# Altium schematic sheet mcu.SchDoc(mcu)
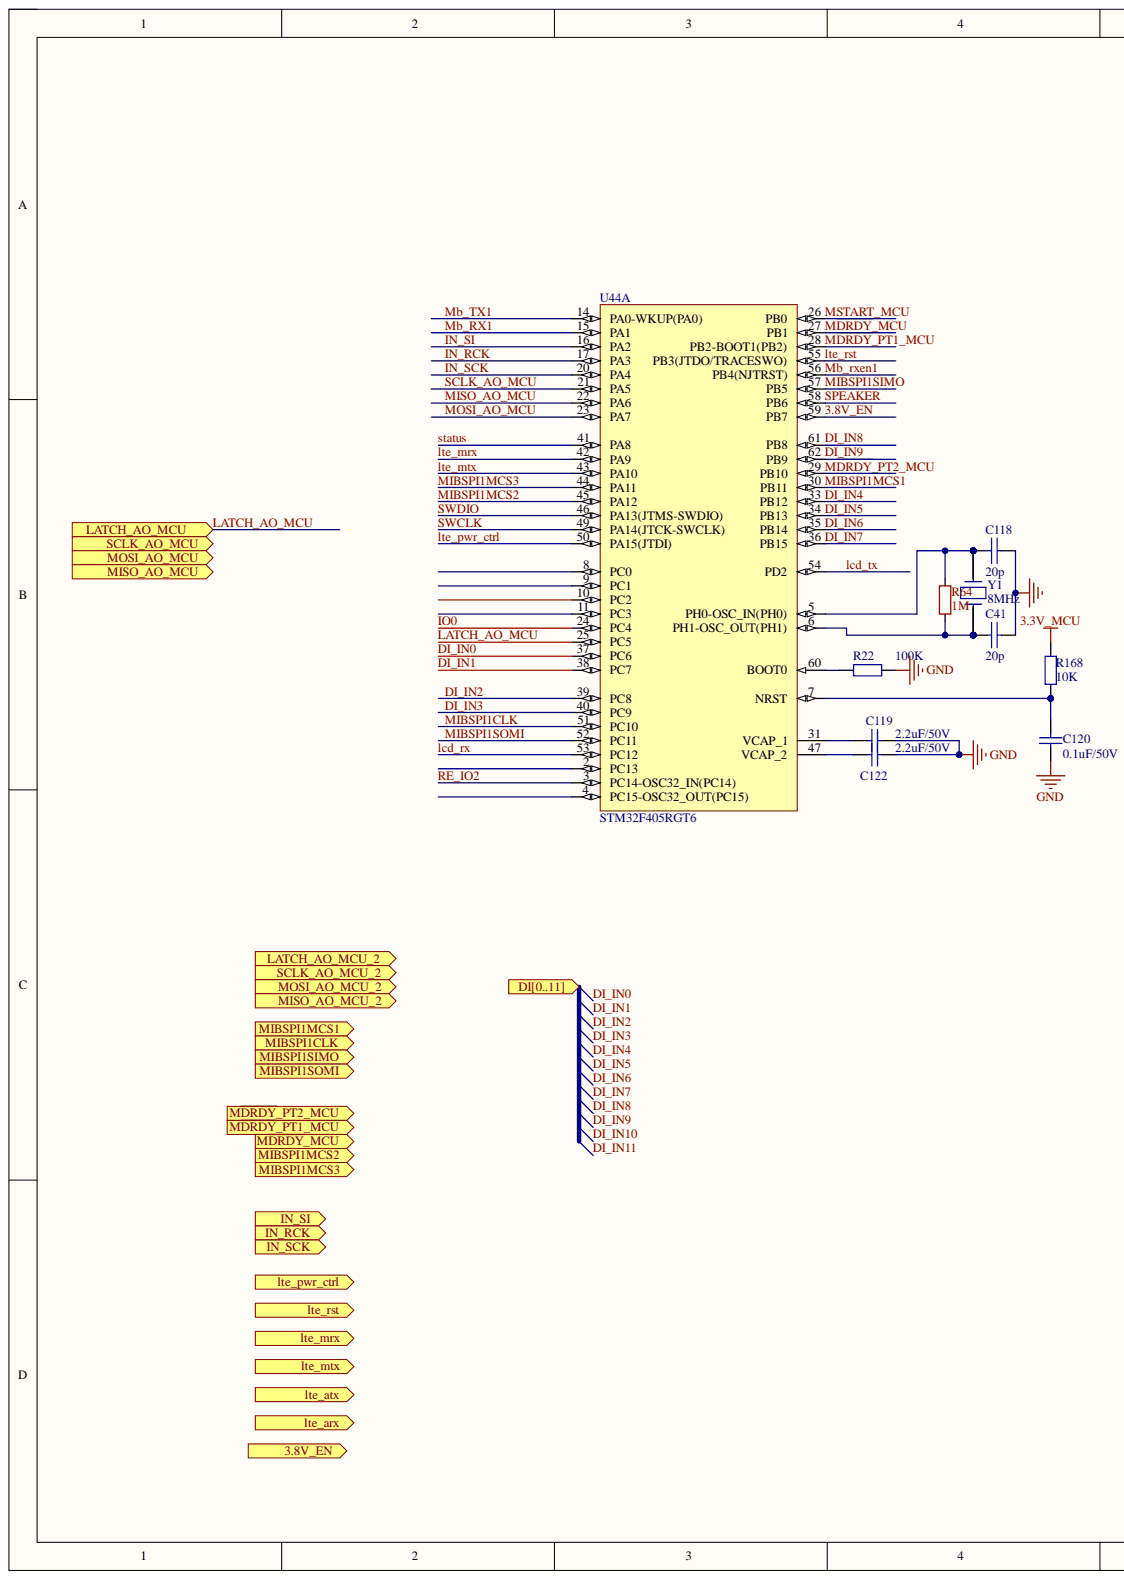
[diagram p0: mcu.SchDoc, left]
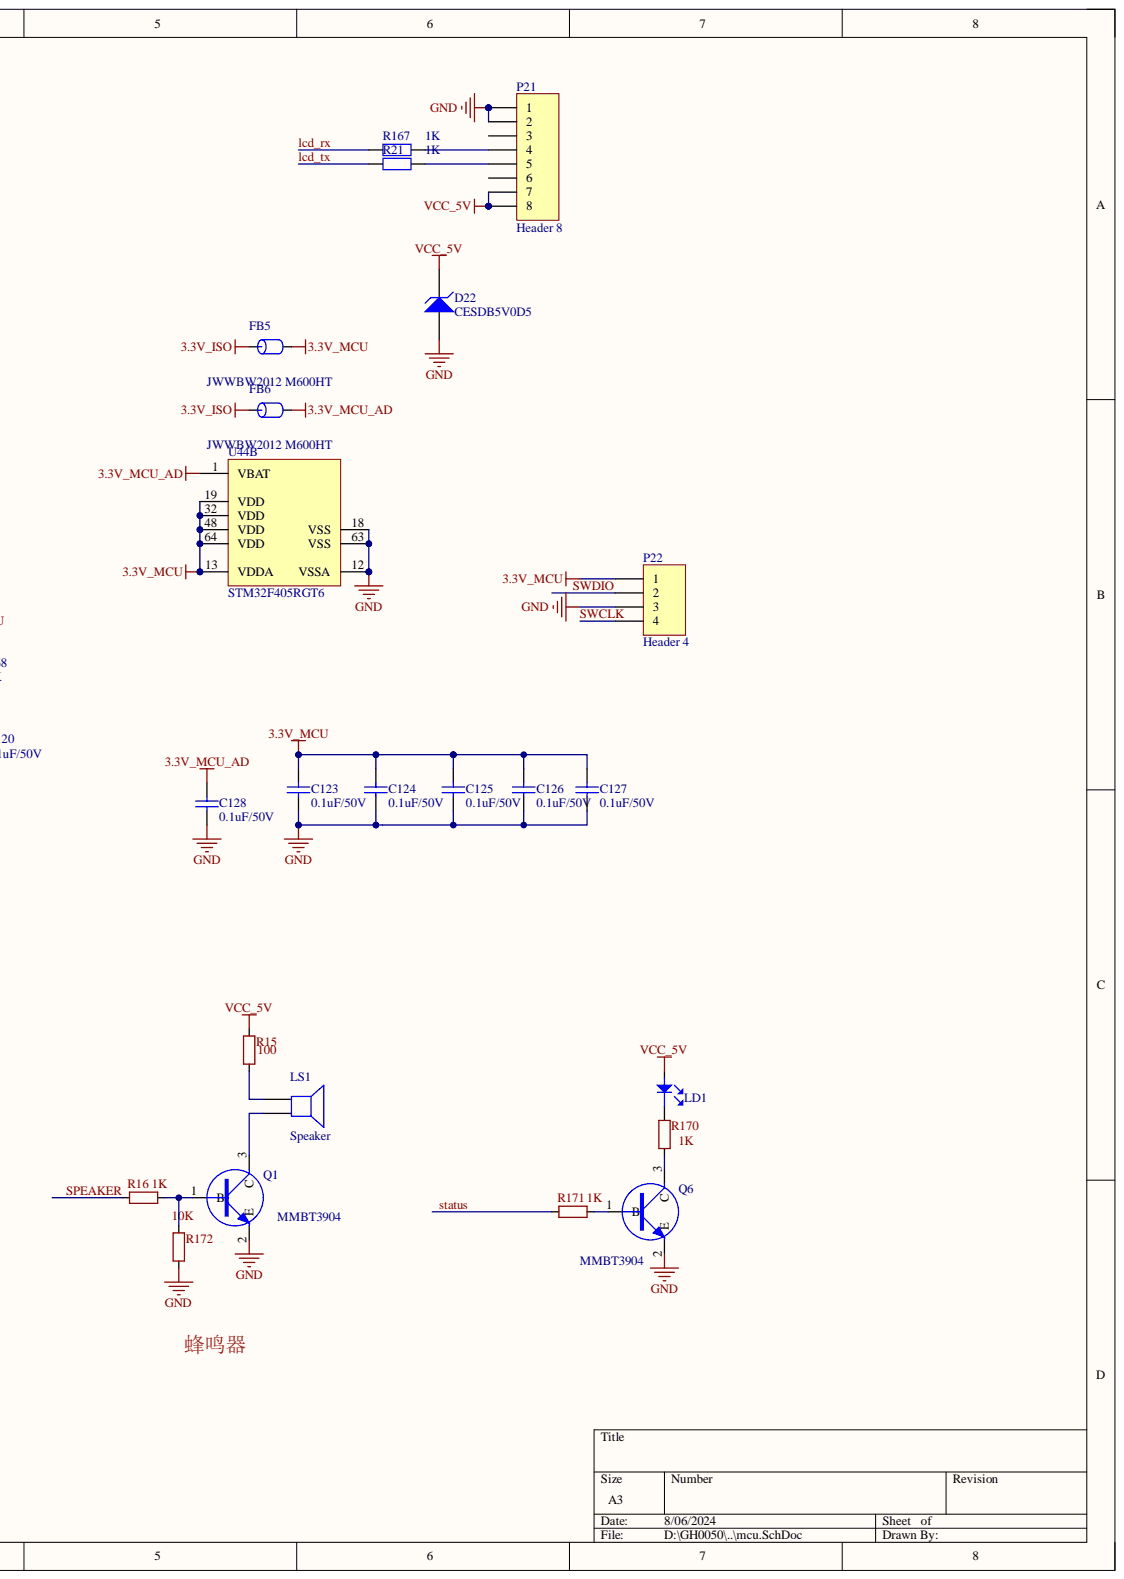
[diagram p1: mcu.SchDoc, right]

## Parts
C41  20p  [0603c]
C118  20p  [0603c]
C119  2.2uF/50V  [0603C]
C120  0.1uF/50V  [0603C]
C122  2.2uF/50V  [0603C]
C123  0.1uF/50V  [0603C]
C124  0.1uF/50V  [0603C]
C125  0.1uF/50V  [0603C]
C126  0.1uF/50V  [0603C]
C127  0.1uF/50V  [0603C]
C128  0.1uF/50V  [0603C]
D22  CESDB5V0D5  [sod323]
FB5  JWWBW2012 M600HT  [0805R]
FB6  JWWBW2012 M600HT  [0805R]
LD1  LED_Green_19-217  [0603led]  (value not drawn)
LS1  Speaker  [BUFFER_0905]
P21  Header 8  [2.0x8]  (no BOM)
P22  Header 4  [HDR1X4]
Q1  MMBT3904  [SOT23-3]
Q6  MMBT3904  [SOT23-3]
R15  100  [0603R]
R16  1K  [0603r]
R21  1K  [0603R]
R22  100K  [0603R]
R64  1M  [0603R]
R167  1K  [0603R]
R168  10K  [0603R]
R170  1K  [0603r]
R171  1K  [0603r]
R172  10K  [0603r]
U44  STM32F405RGT6  [LQFP-64]  (parts A,B)
Y1  8MHz  [CRAY_5032]

## Ports
3.8V_EN, DI0, DI1, DI2, DI3, DI4, DI5, DI6, DI7, DI8, DI9, DI10, DI11, DI[0..11], IN_RCK, IN_SCK, IN_SI, LATCH_AO_MCU, LATCH_AO_MCU_2, lte_arx, lte_atx, lte_mrx, lte_mtx, lte_pwr_ctrl, lte_rst, MIBSPI1CLK, MIBSPI1MCS1, MIBSPI1MCS2, MIBSPI1MCS3, MIBSPI1SIMO, MIBSPI1SOMI, MISO_AO_MCU, MISO_AO_MCU_2, MOSI_AO_MCU, MOSI_AO_MCU_2, M~{DRDY}_MCU, M~{DRDY}_PT1_MCU, M~{DRDY}_PT2_MCU, SCLK_AO_MCU, SCLK_AO_MCU_2

## Nets
3.3V_ISO: FB5.1 FB6.1
3.3V_MCU: C123.2 C124.2 C125.2 C126.2 C127.2 FB5.2 P22.1 R168.2 U44.13(VDDA) U44.19(VDD) U44.32(VDD) U44.48(VDD) U44.64(VDD)
3.3V_MCU_AD: C128.2 FB6.2 U44.1(VBAT)
GND: C41.1 C118.1 C119.1 C120.1 C122.1 C123.1 C124.1 C125.1 C126.1 C127.1 C128.1 D22.1 P21.1 P21.2 P22.3 Q1.2 Q6.2 R22.2 R172.2 U44.12(VSSA) U44.18(VSS) U44.63(VSS)
VCC_5V: D22.2 LD1.1 P21.7 P21.8 R15.1
3.8V_EN: U44.59(PB7)
DI_IN0: U44.37(PC6)
DI_IN1: U44.38(PC7)
DI_IN2: U44.39(PC8)
DI_IN3: U44.40(PC9)
DI_IN4: U44.33(PB12)
DI_IN5: U44.34(PB13)
DI_IN6: U44.35(PB14)
DI_IN7: U44.36(PB15)
DI_IN8: U44.61(PB8)
DI_IN9: U44.62(PB9)
IN_RCK: U44.17(PA3)
IN_SCK: U44.20(PA4)
IN_SI: U44.16(PA2)
IO0: U44.24(PC4)
LATCH_AO_MCU: U44.25(PC5) ; port LATCH_AO_MCU
lcd_rx: R167.1 U44.53(PC12)
lcd_tx: R21.1 U44.54(PD2)
lte_mrx: U44.42(PA9)
lte_mtx: U44.43(PA10)
lte_pwr_ctrl: U44.50(PA15(JTDI))
lte_rst: U44.55(PB3(JTDO/TRACESWO))
Mb_RX1: U44.15(PA1)
Mb_rxen1: U44.56(PB4(NJTRST))
Mb_TX1: U44.14(PA0-WKUP(PA0))
M~{DRDY}_MCU: U44.27(PB1)
M~{DRDY}_PT1_MCU: U44.28(PB2-BOOT1(PB2))
M~{DRDY}_PT2_MCU: U44.29(PB10)
MIBSPI1CLK: U44.51(PC10)
MIBSPI1MCS1: U44.30(PB11)
MIBSPI1MCS2: U44.45(PA12)
MIBSPI1MCS3: U44.44(PA11)
MIBSPI1SIMO: U44.57(PB5)
MIBSPI1SOMI: U44.52(PC11)
MISO_AO_MCU: U44.22(PA6)
MOSI_AO_MCU: U44.23(PA7)
MSTART_MCU: U44.26(PB0)
RE_IO2: U44.3(PC14-OSC32_IN(PC14))
SCLK_AO_MCU: U44.21(PA5)
SPEAKER: R16.2 U44.58(PB6)
status: R171.1 U44.41(PA8)
SWCLK: P22.4 U44.49(PA14(JTCK-SWCLK))
SWDIO: P22.2 U44.46(PA13(JTMS-SWDIO))
NetC41_2: C41.2 R64.1 U44.6(PH1-OSC_OUT(PH1)) Y1.2
NetC118_2: C118.2 R64.2 U44.5(PH0-OSC_IN(PH0)) Y1.1
NetC119_2: C119.2 U44.31(VCAP_1)
NetC120_2: C120.2 R168.1 U44.7(NRST)
NetC122_2: C122.2 U44.47(VCAP_2)
NetLD1_2: LD1.2 R170.1
NetLS1_1: LS1.1 R15.2
NetLS1_2: LS1.2 Q1.3
NetP21_4: P21.4 R167.2
NetP21_5: P21.5 R21.2
NetQ1_1: Q1.1 R16.1 R172.1
NetQ6_1: Q6.1 R171.2
NetQ6_3: Q6.3 R170.2
NetR22_1: R22.1 U44.60(BOOT0)
DI_IN10:
DI_IN11:
N00002_0: ; port DI[0..11]
N00002_1: ; port DI[0..11]
N00002_2: ; port DI[0..11]
N00002_3: ; port DI[0..11]
N00002_4: ; port DI[0..11]
N00002_5: ; port DI[0..11]
N00002_6: ; port DI[0..11]
N00002_7: ; port DI[0..11]
N00002_8: ; port DI[0..11]
N00002_9: ; port DI[0..11]
N00002_10: ; port DI[0..11]
N00002_11: ; port DI[0..11]
N00011: ; port MIBSPI1MCS3
N00013: ; port M~{DRDY}_PT2_MCU
N00017: ; port M~{DRDY}_PT1_MCU
N00019: ; port MIBSPI1SIMO
N00021: ; port MIBSPI1SOMI
N00023: ; port M~{DRDY}_MCU
N00027: ; port MIBSPI1CLK
N00029: ; port MIBSPI1MCS1
N00033: ; port MIBSPI1MCS2
N00037: ; port MISO_AO_MCU_2
N00039: ; port SCLK_AO_MCU_2
N00041: ; port SCLK_AO_MCU
N00043: ; port MOSI_AO_MCU_2
N00045: ; port MOSI_AO_MCU
N00047: ; port MISO_AO_MCU
N00049: ; port LATCH_AO_MCU_2
N00051: ; port IN_RCK
N00053: ; port IN_SCK
N00055: ; port IN_SI
N00057: ; port lte_atx
N00059: ; port lte_rst
N00061: ; port lte_pwr_ctrl
N00063: ; port lte_mtx
N00065: ; port lte_mrx
N00067: ; port lte_arx
N00068: ; port 3.8V_EN

Not connected: P21 3 6; U44 2(PC13) 4(PC15-OSC32_OUT(PC15)) 8(PC0) 9(PC1) 10(PC2) 11(PC3)

## Library data of the parts
C41 C118 = CAP: Capacitor; Component Kind: Standard; Library Name: Schlib.SchLib
C119 C120 C122-C128 = Cap: Capacitor; Value: 22nF; Component Kind: Standard; LatestRevisionDate: 17-Jul-2002; LatestRevisionNote: Re-released for DXP Platform.; Library Name: Miscellaneous Devices.IntLib; PackageDescription: Radial Cap, Thru-Hole; 2 Leads; 0.3 in Pin Spacing; PackageReference: RAD-0.3; Published: 8-Jun-2000; Signal Integrity: Cap; Simulation: CAP; Publisher: Altium Limited
D22 = D Zener: Zener Diode; Component Kind: Standard; LatestRevisionDate: 17-Jul-2002; LatestRevisionNote: Re-released for DXP Platform.; Library Name: Miscellaneous Devices.IntLib; PackageReference: DIODE-0.7; Published: 8-Jun-2000; Signal Integrity: D Zener; Publisher: Altium Limited
FB5 FB6 = FB: Part Field 1: 磁珠/0603/1K ohm/100MHz; FB: BLM18BD102SN1(muRata); Component Kind: Standard; Library Name: Schlib_20110825.schlib; Text Field1: FB
LD1 = LED_Green_19-217: ID: 6; Value: 19-217/G7C-AN1P2/3T; 制造商: Everlight; 器件等级: ——; 工作温度: –40°C to 85°C; 序号: 33; 物料主编码(PN): 100000032; 物料名称: 绿色LED; 物料描述: Other,LED,绿色,0603,1.6X0.8X0.4mm,19-217/G7C-AN1P2/3T; 物料类型: 特殊二极管; Component Kind: Standard; Library Name: RC_M_D_00.IntLib; 规格型号(完整型号): 19-217/G7C-AN1P2/3T
LS1 = Speaker: Loudspeaker; Component Kind: Standard; LatestRevisionDate: 17-Jul-2002; LatestRevisionNote: Re-released for DXP Platform.; Library Name: Miscellaneous Devices.IntLib; PackageReference: PIN2; Published: 24-Mar-1999; Publisher: Altium Limited
P21 = Header 8: Header, 8-Pin; LatestRevisionDate: 17-Jul-2002; LatestRevisionNote: Re-released for DXP Platform.; Library Name: Miscellaneous Connectors.IntLib; Signal Integrity: Connector; Publisher: Altium Limited
P22 = Header 4: Header, 4-Pin; Component Kind: Standard; LatestRevisionDate: 17-Jul-2002; LatestRevisionNote: Re-released for DXP Platform.; Library Name: Miscellaneous Connectors.IntLib; Signal Integrity: Connector; Publisher: Altium Limited
Q1 Q6 = NPN_MMBT3904: Component Kind: Standard; Library Name: CenLib.SCHLIB
R15 R16 R64 R170-R172 = RES0603: ID: 233; 制造商: 三星/YAGEO; 参数值: 1.00E+04; 器件等级: ——; 工作温度: –55°C to 155°C; 序号: 109; 物料主编码(PN): 150000040; 物料名称: 贴片电阻; 物料描述: RES,贴片电阻,0603,10k,±1%,1/10W,1.6X0.8X0.45mm,RC1608F103CS/RC0603FR-0710KL; 物料类型: 表贴电阻; 精度: ±1%; 规格型号(完整型号): RC1608F103CS/RC0603FR-0710KL; Component Kind: Standard; Library Name: RC_M_D_00.IntLib
  R15: Value: 100
  R16 R170 R171: Value: 1K
  R64: Value: 1M
  R172: Value: 10K
R21 R22 R167 R168 = Res0805M: Component Kind: Standard; Library Name: MQlibrary.IntLib
U44 = STM32F405RGT6: ARM Cortex-M4 32-bit MCU+FPU, 210 DMIPS, 1024 kB Flash, 192 kB Internal RAM, 51 I/Os, 64-pin LQFP, -40 to 85 degC, Tray; Manufacturer: STMicroelectronics; D/A Converter: 2x12-bit; FLASH Size (Prog) (kB): 1024; I/Os (High Current): 51; Internal RAM Size (kB): 192; Operating Frequency(F) (Processor speed) (MHz): 168; Supply Voltage(Vcc) max (V): 3.6; Supply Voltage(Vcc) min (V): 1.8; Component Kind: Standard; ComponentLink1Description: Manufacturer URL; ComponentLink1URL: http://www.st.com/web/en/home.html; ComponentLink2Description: Datasheet; ComponentLink2URL: http://www.st.com/st-web-ui/static/active/en/resource/technical/document/datasheet/DM00037051.pdf; ComponentLink3Description: Package Specification; ComponentLink3URL: http://www.st.com/st-web-ui/static/active/en/resource/technical/document/datasheet/DM00037051.pdf; Core: ARM Cortex-M4; DatasheetVersion: Rev. 4; Library Name: STMicroelectronics STM32 F4.IntLib; Mounting Technology: Surface Mount; PackageDescription: 64-Pin Low Profile Quad Flat Package, 10 x 10 mm Body, 0.5 mm Pitch; PackageReference: LQFP64; PackageVersion: Rev. 4, 06/2013; Packing: Tray; PartNumber: STM32F405RGT6; Supplier 1: Digi-Key; Supplier 2: Farnell; Supplier 3: Newark; Supplier 4: Mouser; Supplier Part Number 1: 497-11767-ND; Supplier Part Number 2: 2064363; Supplier Part Number 3: 73T2329; Supplier Part Number 4: 511-STM32F405RGT6
Y1 = CRYSTAL: HC49S-8MHz; Component Kind: Standard
